# Altium schematic sheet SCHEMATIC.SchDoc(SCHEMATIC)
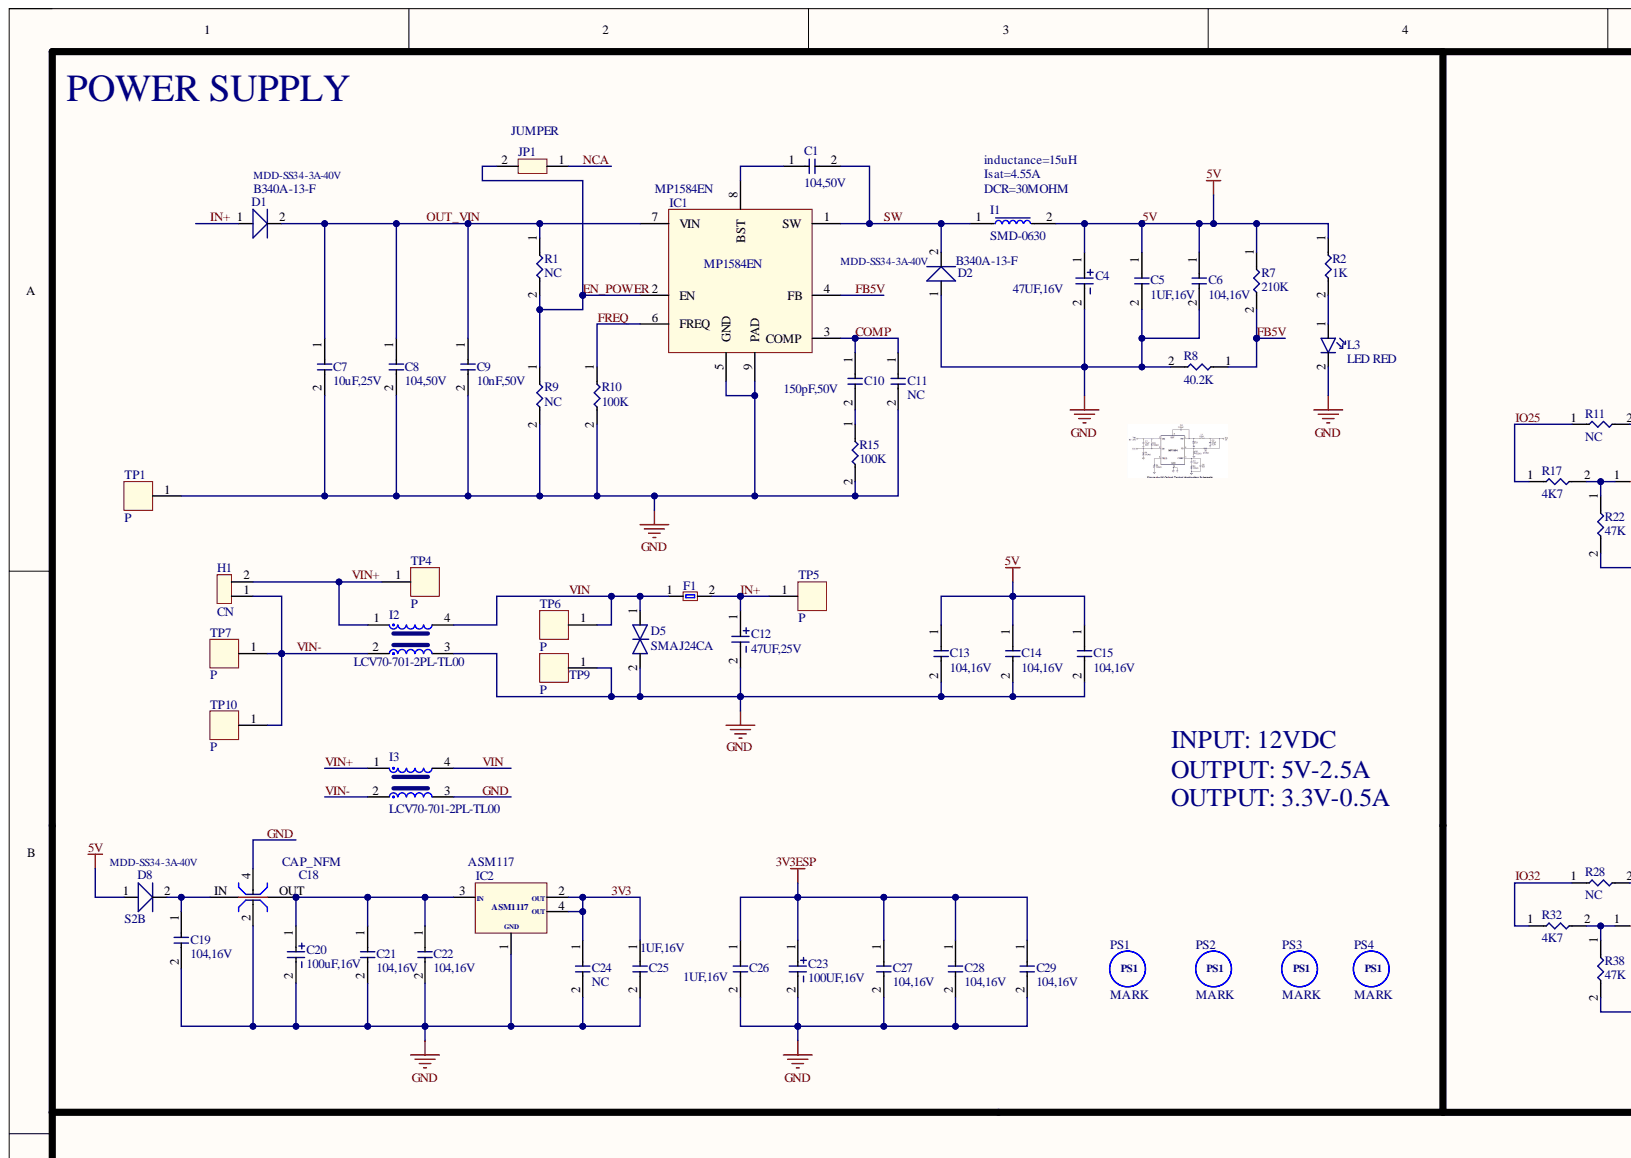
[diagram p0: SCHEMATIC.SchDoc, top left]
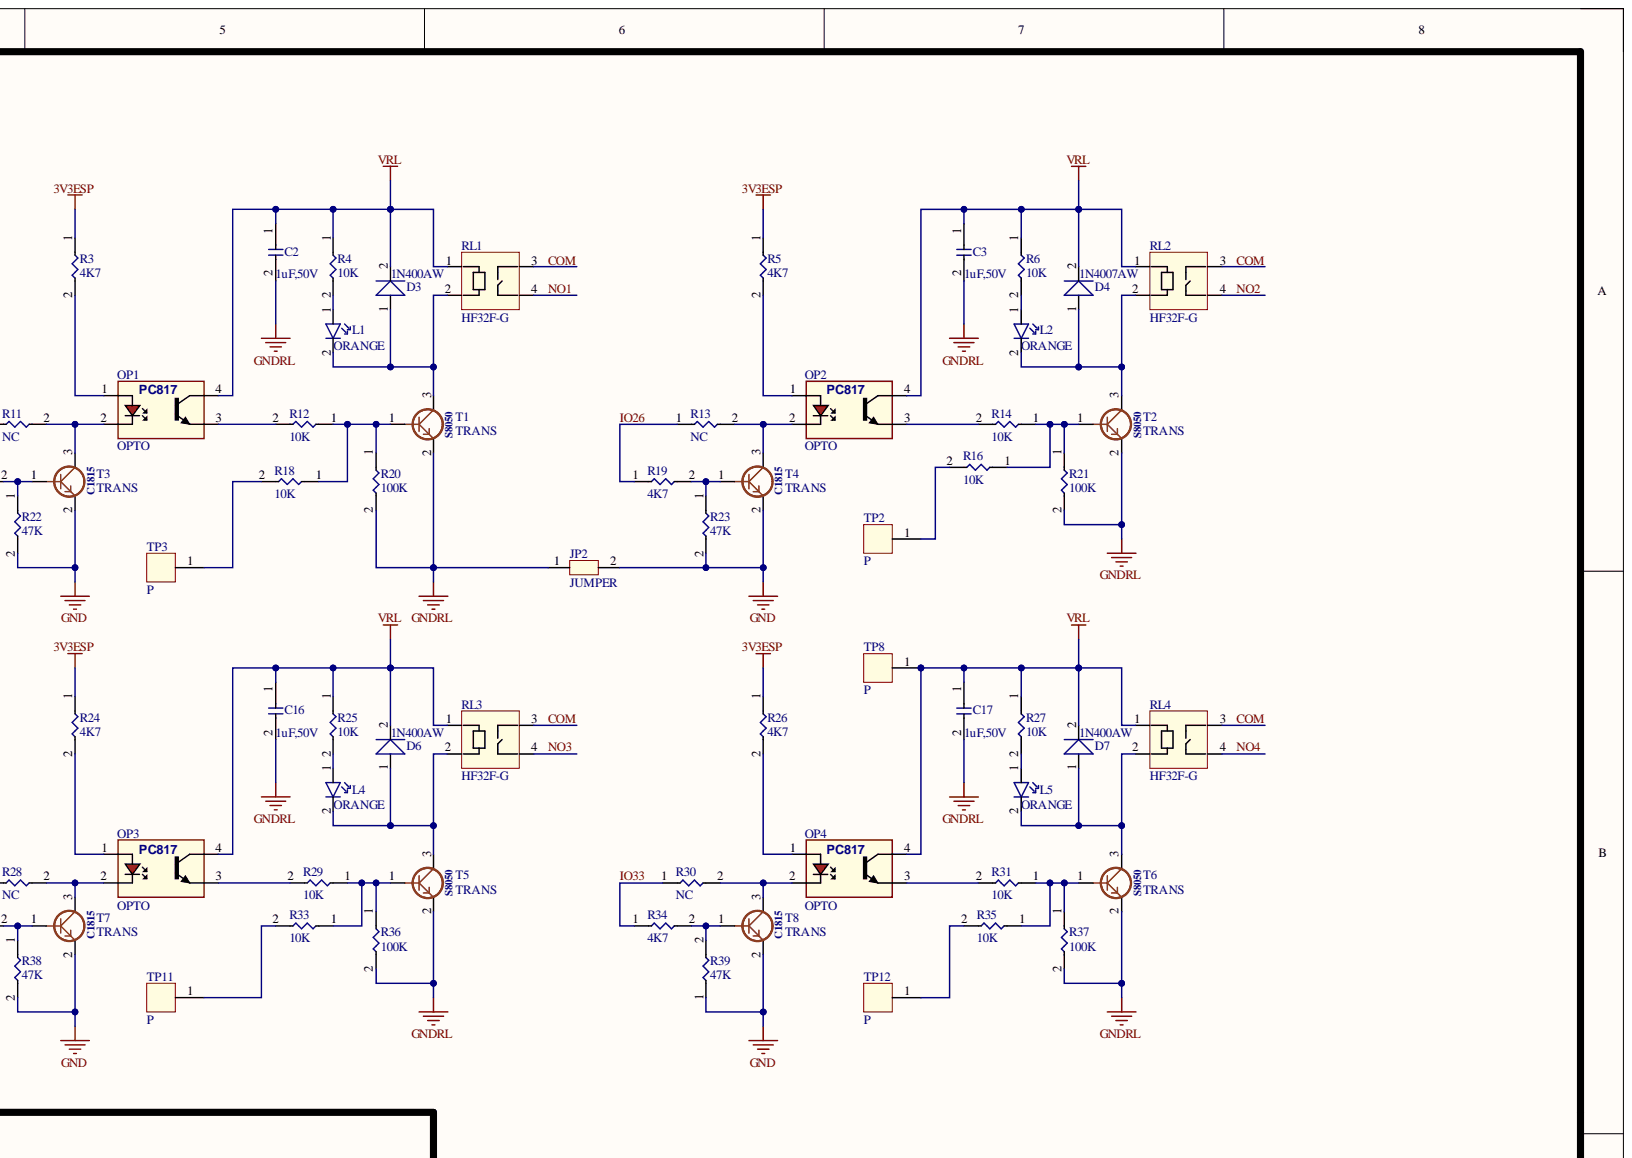
[diagram p1: SCHEMATIC.SchDoc, top right]
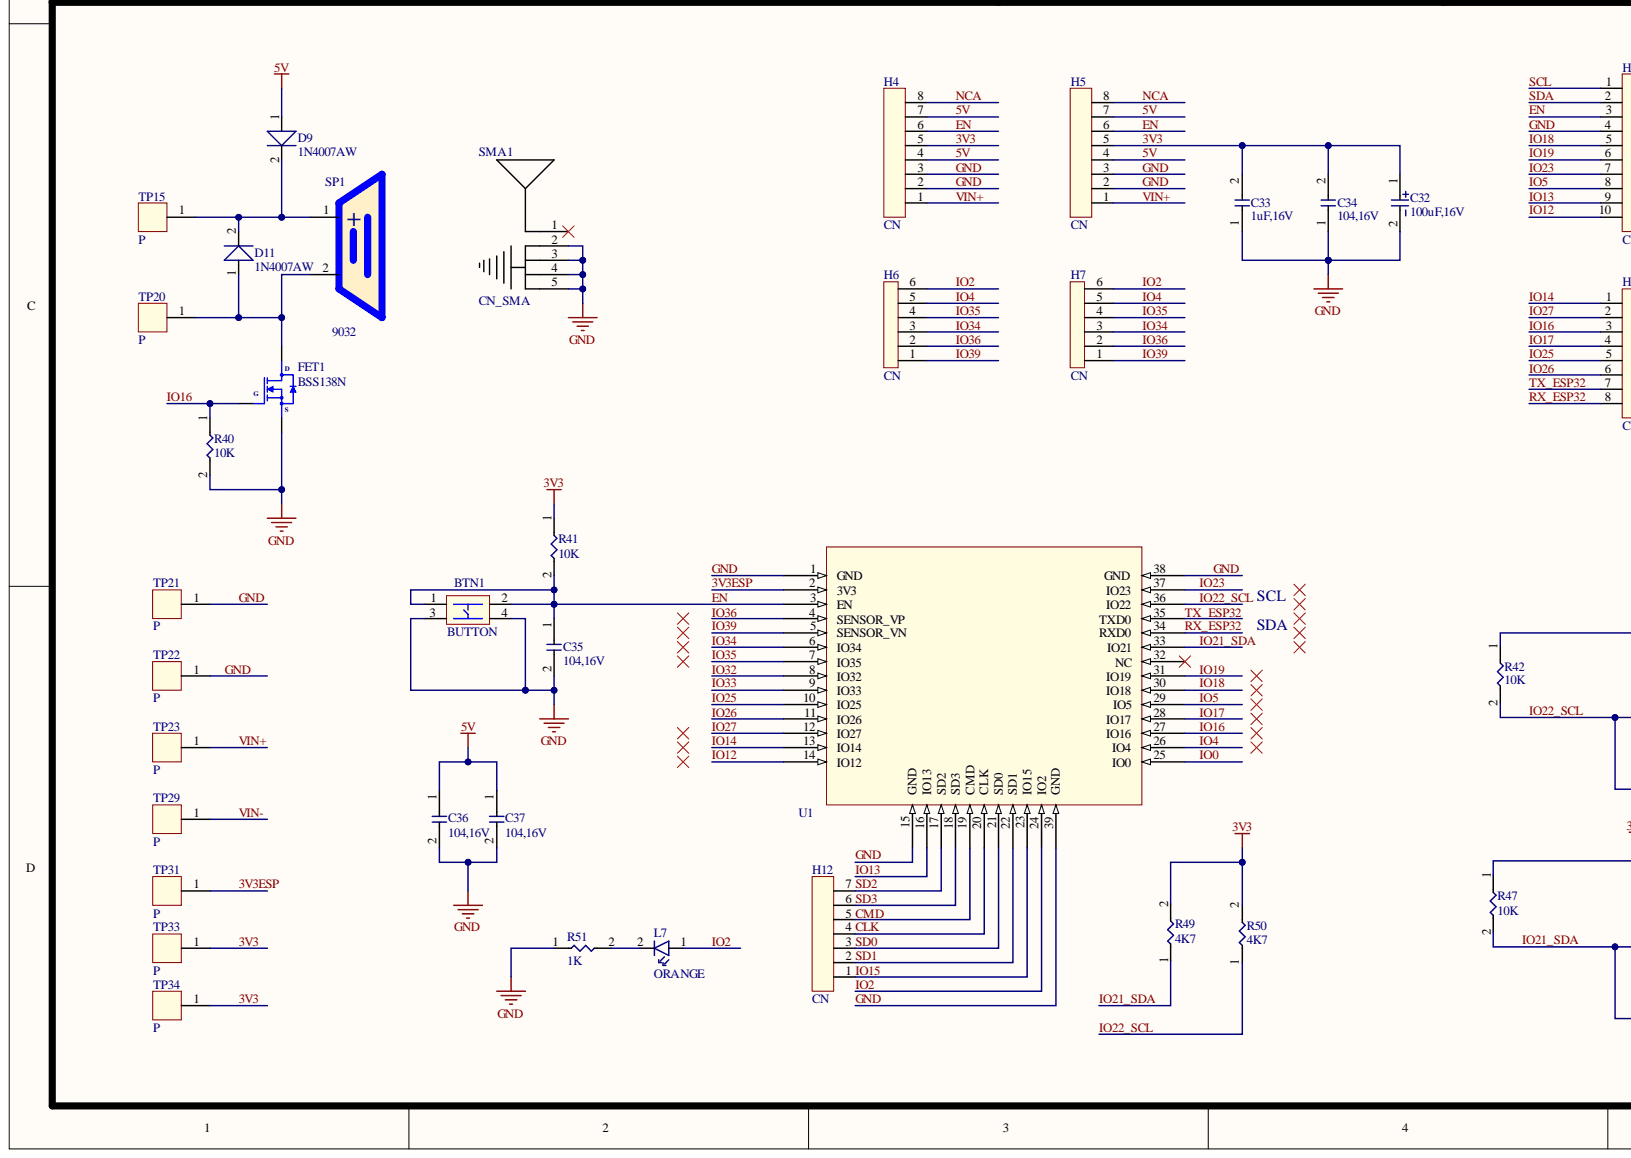
[diagram p2: SCHEMATIC.SchDoc, bottom left]
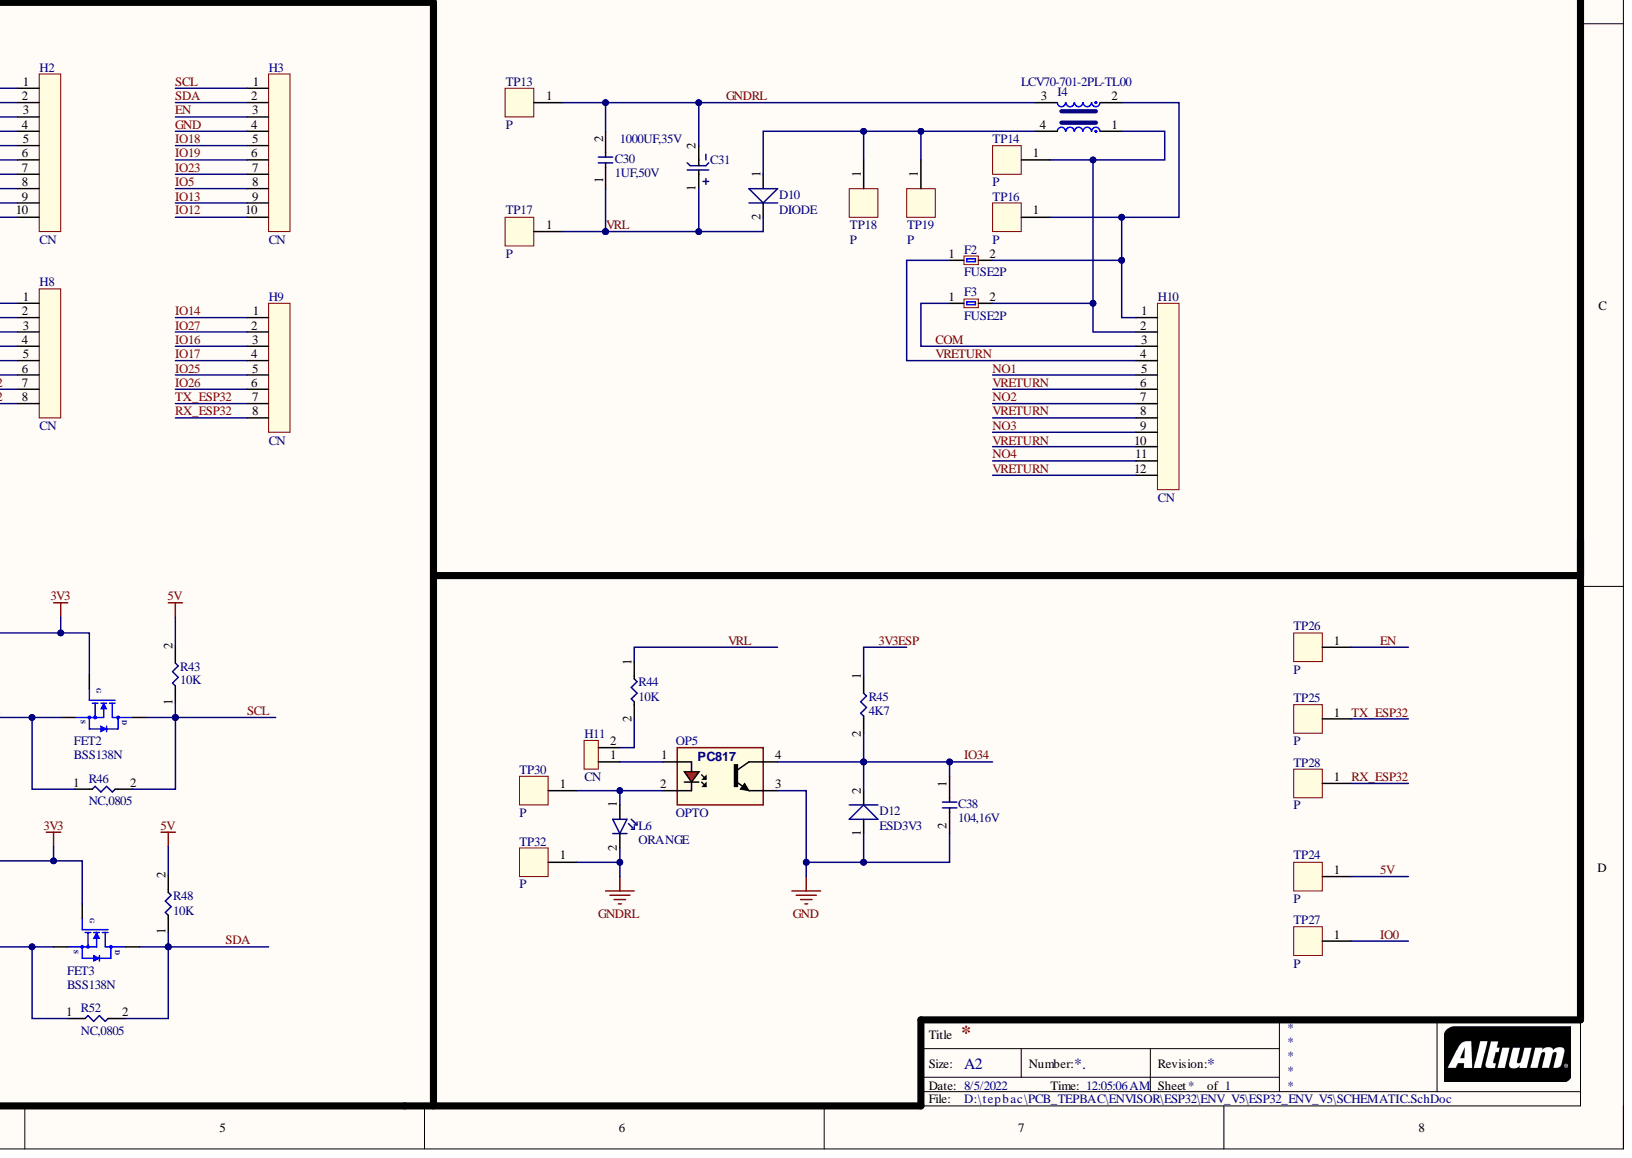
[diagram p3: SCHEMATIC.SchDoc, bottom right]

## Parts
BTN1  BUTTON  [BTN_4X4MM]
C1  104,50V  [cap_smd_0603]
C2  1uF,50V  [cap_smd_0805]
C3  1uF,50V  [cap_smd_0805]
C4  47UF,16V  [CAP_TAN_B]
C5  1UF,16V  [cap_smd_0603]
C6  104,16V  [cap_smd_0603]
C7  10uF,25V  [CAP_SMD_1210]
C8  104,50V  [cap_smd_0603]
C9  10nF,50V  [cap_smd_0603]
C10  150pF,50V  [cap_smd_0603]
C11  NC  [cap_smd_0603]
C12  47UF,25V  [CAP_TAN_D]
C13  104,16V  [cap_smd_0603]
C14  104,16V  [cap_smd_0603]
C15  104,16V  [cap_smd_0603]
C16  1uF,50V  [cap_smd_0805]
C17  1uF,50V  [cap_smd_0805]
C18  CAP_NFM  [NFM21PC105B1A3D]
C19  104,16V  [cap_smd_0603]
C20  100uF,16V  [CAP_TAN_B]
C21  104,16V  [cap_smd_0603]
C22  104,16V  [cap_smd_0603]
C23  100UF,16V  [CAP_TAN_B]
C24  NC  [cap_smd_0805]
C25  1UF,16V  [cap_smd_0603]
C26  1UF,16V  [cap_smd_0603]
C27  104,16V  [cap_smd_0603]
C28  104,16V  [cap_smd_0603]
C29  104,16V  [cap_smd_0603]
C30  1UF,50V  [cap_smd_0805]
C31  1000UF,35V  [CAP_POL_DIP]
C32  100uF,16V  [CAP_TAN_B]
C33  1uF,16V  [cap_smd_0603]
C34  104,16V  [cap_smd_0603]
C35  104,16V  [cap_smd_0603]
C36  104,16V  [cap_smd_0603]
C37  104,16V  [cap_smd_0603]
C38  104,16V  [cap_smd_0603]
D1  B340A-13-F  [diode_smd_DO_214AC]
D2  B340A-13-F  [diode_smd_DO_214AC]
D3  1N400AW  [DIODE_SMD_SOD_123]
D4  1N4007AW  [DIODE_SMD_SOD_123]
D5  SMAJ24CA  [TVS_214AC]
D6  1N400AW  [DIODE_SMD_SOD_123]
D7  1N400AW  [DIODE_SMD_SOD_123]
D8  S2B  [DIODE_SMD_SOD_123]
D9  1N4007AW  [DIODE_SMD_SOD_123]
D10  DIODE  [DIODE_SMD_SOD_123]
D11  1N4007AW  [DIODE_SMD_SOD_123]
D12  ESD3V3  [DIODE_SMD_SOD_323]
F1  (no value)  [fuse_1812]
F2  FUSE2P  [fuse_1812]
F3  FUSE2P  [fuse_1812]
FET1  BSS138N  [BSS138_N]
FET2  BSS138N  [BSS138_N]
FET3  BSS138N  [BSS138_N]
H1  CN  [TER2P_381MM]
H2  CN  [10X01P_C_T]
H3  CN  [10X01P_C_T]
H4  CN  [8X01P_C_T]
H5  CN  [8X01P_C_T]
H6  CN  [6X01P_C_T]
H7  CN  [6X01P_C_T]
H8  CN  [8X01P_C_T]
H9  CN  [8X01P_C_T]
H10  CN  [1X12_VER_381MM]
H11  CN  [1x2P_TER_381MM]
H12  CN  [7X01P_T]
I1  SMD-0630  [0630]
I2  LCV70-701-2PL-TL00  [ACM12V-701-2PL-TL00]
I3  LCV70-701-2PL-TL00  [LCV70-701-2PL-TL00]
I4  LCV70-701-2PL-TL00  [ACM12V-701-2PL-TL00]
IC1  MP1584EN  [MP1584EN-LF-Z]
IC2  ASM117  [ASM1117]
JP1  JUMPER  [jum_power]
JP2  JUMPER  [jum_power]
L1  ORANGE  [led_0603_blue]
L2  ORANGE  [led_0603_blue]
L3  LED RED  [led_0603_blue]
L4  ORANGE  [led_0603_blue]
L5  ORANGE  [led_0603_blue]
L6  ORANGE  [led_0603_blue]
L7  ORANGE  [led_0603_blue]
OP1  OPTO  [PC817_SMD]
OP2  OPTO  [PC817_SMD]
OP3  OPTO  [PC817_SMD]
OP4  OPTO  [PC817_SMD]
OP5  OPTO  [PC817_SMD]
PS1  MARK  [ps1]
PS2  MARK  [ps1]
PS3  MARK  [ps1]
PS4  MARK  [ps1]
R1  NC  [0603_R_L]
R2  1K  [0603_R_L]
R3  4K7  [0603_R_L]
R4  10K  [0603_R_L]
R5  4K7  [0603_R_L]
R6  10K  [0603_R_L]
R7  210K  [0603_R_L]
R8  40.2K  [0603_R_L]
R9  NC  [0603_R_L]
R10  100K  [0603_R_L]
R11  NC  [0603_R_L]
R12  10K  [0603_R_L]
R13  NC  [0603_R_L]
R14  10K  [0603_R_L]
R15  100K  [0603_R_L]
R16  10K  [0603_R_L]
R17  4K7  [0603_R_L]
R18  10K  [0603_R_L]
R19  4K7  [0603_R_L]
R20  100K  [0603_R_L]
R21  100K  [0603_R_L]
R22  47K  [0603_R_L]
R23  47K  [0603_R_L]
R24  4K7  [0603_R_L]
R25  10K  [0603_R_L]
R26  4K7  [0603_R_L]
R27  10K  [0603_R_L]
R28  NC  [0603_R_L]
R29  10K  [0603_R_L]
R30  NC  [0603_R_L]
R31  10K  [0603_R_L]
R32  4K7  [0603_R_L]
R33  10K  [0603_R_L]
R34  4K7  [0603_R_L]
R35  10K  [0603_R_L]
R36  100K  [0603_R_L]
R37  100K  [0603_R_L]
R38  47K  [0603_R_L]
R39  47K  [0603_R_L]
R40  10K  [0603_R_L]
R41  10K  [0603_R_L]
R42  10K  [0603_R_L]
R43  10K  [0603_R_L]
R44  10K  [0805_R_L]
R45  4K7  [0603_R_L]
R46  NC,0805  [0603_R_L]
R47  10K  [0603_R_L]
R48  10K  [0603_R_L]
R49  4K7  [0603_R_L]
R50  4K7  [0603_R_L]
R51  1K  [0603_R_L]
R52  NC,0805  [0603_R_L]
RL1  HF32F-G  [HF32F-G-JZC-32F-G]
RL2  HF32F-G  [HF32F-G-JZC-32F-G]
RL3  HF32F-G  [HF32F-G-JZC-32F-G]
RL4  HF32F-G  [HF32F-G-JZC-32F-G]
SMA1  CN_SMA  [SMA_0731000114]
SP1  9032  [SMD_BUZZER]
T1  TRANS  [S8050]
T2  TRANS  [S8050]
T3  TRANS  [C1815]
T4  TRANS  [C1815]
T5  TRANS  [S8050]
T6  TRANS  [S8050]
T7  TRANS  [C1815]
T8  TRANS  [C1815]
TP1  P  [TP]
TP2  P  [TP]
TP3  P  [TP]
TP4  P  [TP]
TP5  P  [TP]
TP6  P  [TP]
TP7  P  [TP]
TP8  P  [TP]
TP9  P  [TP]
TP10  P  [TP]
TP11  P  [TP]
TP12  P  [TP]
TP13  P  [TP]
TP14  P  [TP]
TP15  P  [TP]
TP16  P  [TP]
TP17  P  [TP]
TP18  P  [TP]
TP19  P  [TP]
TP20  P  [TP]
TP21  P  [TP]
TP22  P  [TP]
TP23  P  [TP]
TP24  P  [TP]
TP25  P  [TP]
TP26  P  [TP]
TP27  P  [TP]
TP28  P  [TP]
TP29  P  [TP]
TP30  P  [TP]
TP31  P  [TP]
TP32  P  [TP]
TP33  P  [TP]
TP34  P  [TP]
U1  ESP32-WROOM-32  [ESP32-WROOM-32D]  (value not drawn)

## Nets
3V3: C24.1 C25.1 C32.1 C33.2 C34.2 FET2.1 FET3.1 H4.5 H5.5 IC2.2(OUT) IC2.4(OUT) R41.1 R42.1 R47.1 R49.2 R50.2 TP33.1 TP34.1
3V3ESP: C23.1 C26.1 C27.1 C28.1 C29.1 R3.1 R5.1 R24.1 R26.1 R45.1 TP31.1 U1.2(3V3)
5V: C4.1 C5.1 C6.1 C13.1 C14.1 C15.1 C36.1 C37.1 D8.1 D9.1 H4.4 H4.7 H5.4 H5.7 I1.2 R2.1 R7.1 R43.2 R48.2 TP24.1
CLK: H12.4 U1.20(CLK)
CMD: H12.5 U1.19(CMD)
COM: F3.1 H10.3 RL1.3 RL2.3 RL3.3 RL4.3
COMP: C10.1 C11.1 IC1.3(COMP)
EN: BTN1.1 BTN1.2 C35.1 H2.3 H3.3 H4.6 H5.6 R41.2 TP26.1 U1.3(EN)
EN_POWER: IC1.2(EN) JP1.2 R1.2 R9.1
FB5V: IC1.4(FB) R7.2 R8.1
FREQ: IC1.6(FREQ) R10.1
GND: BTN1.3 BTN1.4 C4.2 C5.2 C6.2 C7.2 C8.2 C9.2 C11.2 C12.2 C13.2 C14.2 C15.2 C18.2 C18.4 C19.2 C20.2 C21.2 C22.2 C23.2 C24.2 C25.2 C26.2 C27.2 C28.2 C29.2 C32.2 C33.1 C34.1 C35.2 C36.2 C37.2 C38.2 D2.1 D5.2 D12.1 FET1.2 H2.4 H3.4 H4.2 H4.3 H5.2 H5.3 I2.3 I3.3 IC1.5(GND) IC1.9(PAD) IC2.1 JP2.2 L3.2 OP5.3 R8.2 R9.2 R10.2 R15.2 R22.2 R23.2 R38.2 R39.1 R40.2 R51.1 SMA1.2 SMA1.3 SMA1.4 SMA1.5 T3.2 T4.2 T7.2 T8.2 TP1.1 TP9.1 TP21.1 TP22.1 U1.1(GND) U1.15(GND) U1.38(GND) U1.39(GND)
GNDRL: C2.2 C3.2 C16.2 C17.2 C30.2 C31.2 I4.3 JP2.1 L6.2 R20.2 R21.2 R36.2 R37.2 T1.2 T2.2 T5.2 T6.2 TP13.1 TP32.1
IN+: C12.1 D1.1 F1.2 TP5.1
IO0: TP27.1 U1.25(IO0)
IO2: H6.6 H7.6 L7.1 U1.24(IO2)
IO4: H6.5 H7.5 U1.26(IO4)
IO5: H2.8 H3.8 U1.29(IO5)
IO12: H2.10 H3.10 U1.14(IO12)
IO13: H2.9 H3.9 U1.16(IO13)
IO14: H8.1 H9.1 U1.13(IO14)
IO15: H12.1 U1.23(IO15)
IO16: FET1.1 H8.3 H9.3 R40.1 U1.27(IO16)
IO17: H8.4 H9.4 U1.28(IO17)
IO18: H2.5 H3.5 U1.30(IO18)
IO19: H2.6 H3.6 U1.31(IO19)
IO21_SDA: FET3.2 R47.2 R49.1 R52.1 U1.33(IO21)
IO22_SCL: FET2.2 R42.2 R46.1 R50.1 U1.36(IO22)
IO23: H2.7 H3.7 U1.37(IO23)
IO25: H8.5 H9.5 R11.1 R17.1 U1.10(IO25)
IO26: H8.6 H9.6 R13.1 R19.1 U1.11(IO26)
IO27: H8.2 H9.2 U1.12(IO27)
IO32: R28.1 R32.1 U1.8(IO32)
IO33: R30.1 R34.1 U1.9(IO33)
IO34: C38.1 D12.2 H6.3 H7.3 OP5.4 R45.2 U1.6(IO34)
IO35: H6.4 H7.4 U1.7(IO35)
IO36: H6.2 H7.2 U1.4(SENSOR_VP)
IO39: H6.1 H7.1 U1.5(SENSOR_VN)
NCA: H4.8 H5.8 JP1.1
NO1: H10.5 RL1.4
NO2: H10.7 RL2.4
NO3: H10.9 RL3.4
NO4: H10.11 RL4.4
OUT_VIN: C7.1 C8.1 C9.1 D1.2 IC1.7(VIN) R1.1
RX_ESP32: H8.8 H9.8 TP28.1 U1.34(RXD0)
SCL: FET2.3 H2.1 H3.1 R43.1 R46.2
SD0: H12.3 U1.21(SD0)
SD1: H12.2 U1.22(SD1)
SD2: H12.7 U1.17(SD2)
SD3: H12.6 U1.18(SD3)
SDA: FET3.3 H2.2 H3.2 R48.1 R52.2
SW: C1.2 D2.2 I1.1 IC1.1(SW)
TX_ESP32: H8.7 H9.7 TP25.1 U1.35(TXD0)
VIN: D5.1 F1.1 I2.4 I3.4 TP6.1
VIN+: H1.2 H4.1 H5.1 I2.1 I3.1 TP4.1 TP23.1
VIN-: H1.1 I2.2 I3.2 TP7.1 TP10.1 TP29.1
VRETURN: F2.1 H10.4 H10.6 H10.8 H10.10 H10.12
VRL: C2.1 C3.1 C16.1 C17.1 C30.1 C31.1 D3.2 D4.2 D6.2 D7.2 D10.2 OP1.4 OP2.4 OP3.4 OP4.4 R4.1 R6.1 R25.1 R27.1 R44.1 RL1.1 RL2.1 RL3.1 RL4.1 TP8.1 TP17.1
NetC1_1: C1.1 IC1.8(BST)
NetC10_2: C10.2 R15.1
NetC18_IN: C18.IN C19.1 D8.2
NetC18_OUT: C18.OUT C20.1 C21.1 C22.1 IC2.3(IN)
NetD3_1: D3.1 L1.2 RL1.2 T1.3
NetD4_1: D4.1 L2.2 RL2.2 T2.3
NetD6_1: D6.1 L4.2 RL3.2 T5.3
NetD7_1: D7.1 L5.2 RL4.2 T6.3
NetD9_2: D9.2 D11.2 SP1.1 TP15.1
NetD10_1: D10.1 I4.4 TP18.1 TP19.1
NetD11_1: D11.1 FET1.3 SP1.2 TP20.1
NetF2_2: F2.2 H10.1 I4.2 TP16.1
NetF3_2: F3.2 H10.2 I4.1 TP14.1
NetH11_1: H11.1 OP5.1(PC817)
NetH11_2: H11.2 R44.2
NetL1_1: L1.1 R4.2
NetL2_1: L2.1 R6.2
NetL3_1: L3.1 R2.2
NetL4_1: L4.1 R25.2
NetL5_1: L5.1 R27.2
NetL6_1: L6.1 OP5.2 TP30.1
NetL7_2: L7.2 R51.2
NetOP1_1: OP1.1(PC817) R3.2
NetOP1_2: OP1.2 R11.2 T3.3
NetOP1_3: OP1.3 R12.2
NetOP2_1: OP2.1(PC817) R5.2
NetOP2_2: OP2.2 R13.2 T4.3
NetOP2_3: OP2.3 R14.2
NetOP3_1: OP3.1(PC817) R24.2
NetOP3_2: OP3.2 R28.2 T7.3
NetOP3_3: OP3.3 R29.2
NetOP4_1: OP4.1(PC817) R26.2
NetOP4_2: OP4.2 R30.2 T8.3
NetOP4_3: OP4.3 R31.2
NetR12_1: R12.1 R18.1 R20.1 T1.1
NetR14_1: R14.1 R16.1 R21.1 T2.1
NetR16_2: R16.2 TP2.1
NetR17_2: R17.2 R22.1 T3.1
NetR18_2: R18.2 TP3.1
NetR19_2: R19.2 R23.1 T4.1
NetR29_1: R29.1 R33.1 R36.1 T5.1
NetR31_1: R31.1 R35.1 R37.1 T6.1
NetR32_2: R32.2 R38.1 T7.1
NetR33_2: R33.2 TP11.1
NetR34_2: R34.2 R39.2 T8.1
NetR35_2: R35.2 TP12.1

Not connected: SMA1 1; U1 32(NC)

## Library data of the parts
BTN1 = BTN4P: Component Kind: Standard; Library Name: COMPONENT.IntLib
C1-C3 C5-C11 C13-C17 C19 C21 C22 C24-C30 C33-C38 = CAPACITOR: Component Kind: Standard; Library Name: COMPONENT.IntLib
C4 C12 C20 C23 C32 = CAP_TAN: Component Kind: Standard; Library Name: COMPONENT.IntLib
C31 = CAP_ALU: Component Kind: Standard; Library Name: COMPONENT.IntLib
D1-D4 D6-D9 D11 D12 = DIODE: Component Kind: Standard; Library Name: COMPONENT.IntLib
D5 = TVS_DIODE: Component Kind: Standard; Library Name: COMPONENT.IntLib
F1-F3 = FUSE: Component Kind: Standard; Library Name: COMPONENT.IntLib
FET1-FET3 = BSS138_TYPE_N: Component Kind: Standard; Library Name: COMPONENT.IntLib
H1 H11 = 2x01p: Component Kind: Standard; Library Name: COMPONENT.IntLib
H2 H3 = 10X01P: Component Kind: Standard; Library Name: COMPONENT.IntLib
H4 H5 H8 H9 = 8x01p: Component Kind: Standard; Library Name: COMPONENT.IntLib
H6 H7 = 6x01p: Component Kind: Standard; Library Name: COMPONENT.IntLib
H10 = 12X01P: Component Kind: Standard; Library Name: COMPONENT.IntLib
H12 = 7x01p: Component Kind: Standard; Library Name: COMPONENT.IntLib
I1 = INDUCTOR: INDUCTOR ,CD47,100,150UH,SIZE 12X12X7; Component Kind: Standard; Library Name: COMPONENT.IntLib
I2-I4 = FILTER_CM_CHOKES: Component Kind: Standard; Library Name: COMPONENT.IntLib
IC1 = MP1584EN-LF-Z: Component Kind: Standard; Library Name: POWER_SUPPLY_ICs.IntLib
IC2 = ASM1117: Component Kind: Standard; Library Name: DC_TO_DC.IntLib
JP1 JP2 = JP_POWER: Component Kind: Standard; Library Name: COMPONENT.IntLib
L1-L7 = LED: Component Kind: Standard; Library Name: COMPONENT.IntLib
OP1-OP5 = PC817: Component Kind: Standard; Library Name: COMPONENT.IntLib
PS1-PS4 = PS1: Component Kind: Standard; Library Name: COMPONENT.IntLib
R1-R52 = RESISTOR: Component Kind: Standard; Description: resistor; Library Name: COMPONENT.IntLib
SP1 = BUZZER: Component Kind: Standard; Library Name: COMPONENT.IntLib
T1 T2 T5 T6 = S8050: Component Kind: Standard; Library Name: COMPONENT.IntLib
T3 T4 T7 T8 = C1815: Component Kind: Standard; Library Name: COMPONENT.IntLib
TP1-TP34 = TP: Component Kind: Standard; Library Name: COMPONENT.IntLib
U1 = ESP32-WROOM-32: ESP32; Manufacturer: Espressif Inc.; Reviewer: Fenrrich; Component Kind: Standard; ComponentLink1Description: Datasheet; ComponentLink1URL: https://www.espressif.com/sites/default/files/documentation/esp32-wroom-32_datasheet_en.pdf; Part Number: ESP32-WROOM-32; Data Reviewer: 03-May-2019; Library Name: wriless_module.IntLib; Publisher: Cynetik Group
C18 = CAP_NFM: Component Kind: Standard; Library Name: COMPONENT.IntLib
D10 = DIODE: Component Kind: Standard; Library Name: COMPONENT.IntLib
RL1-RL4 = HF32F-G: Component Kind: Standard; Library Name: COMPONENT.IntLib
SMA1 = CN_SMA: Component Kind: Standard; Library Name: COMPONENT.IntLib
